# Altium schematic sheet VELOSTAT_SENSING.SchDoc
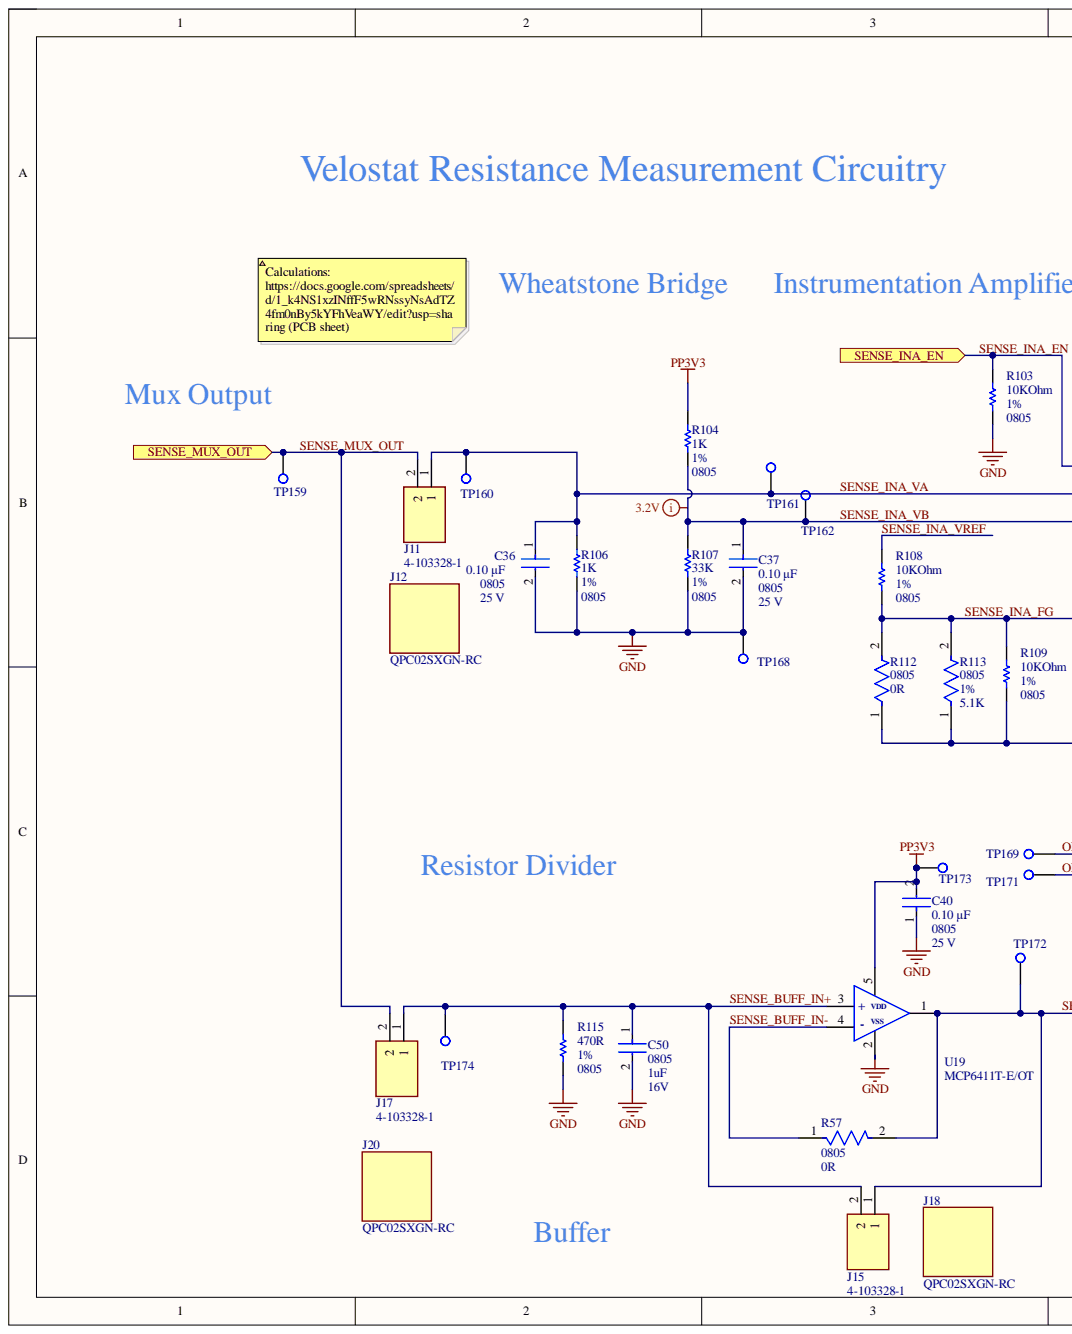
[diagram p0: VELOSTAT_SENSING.SchDoc, left]
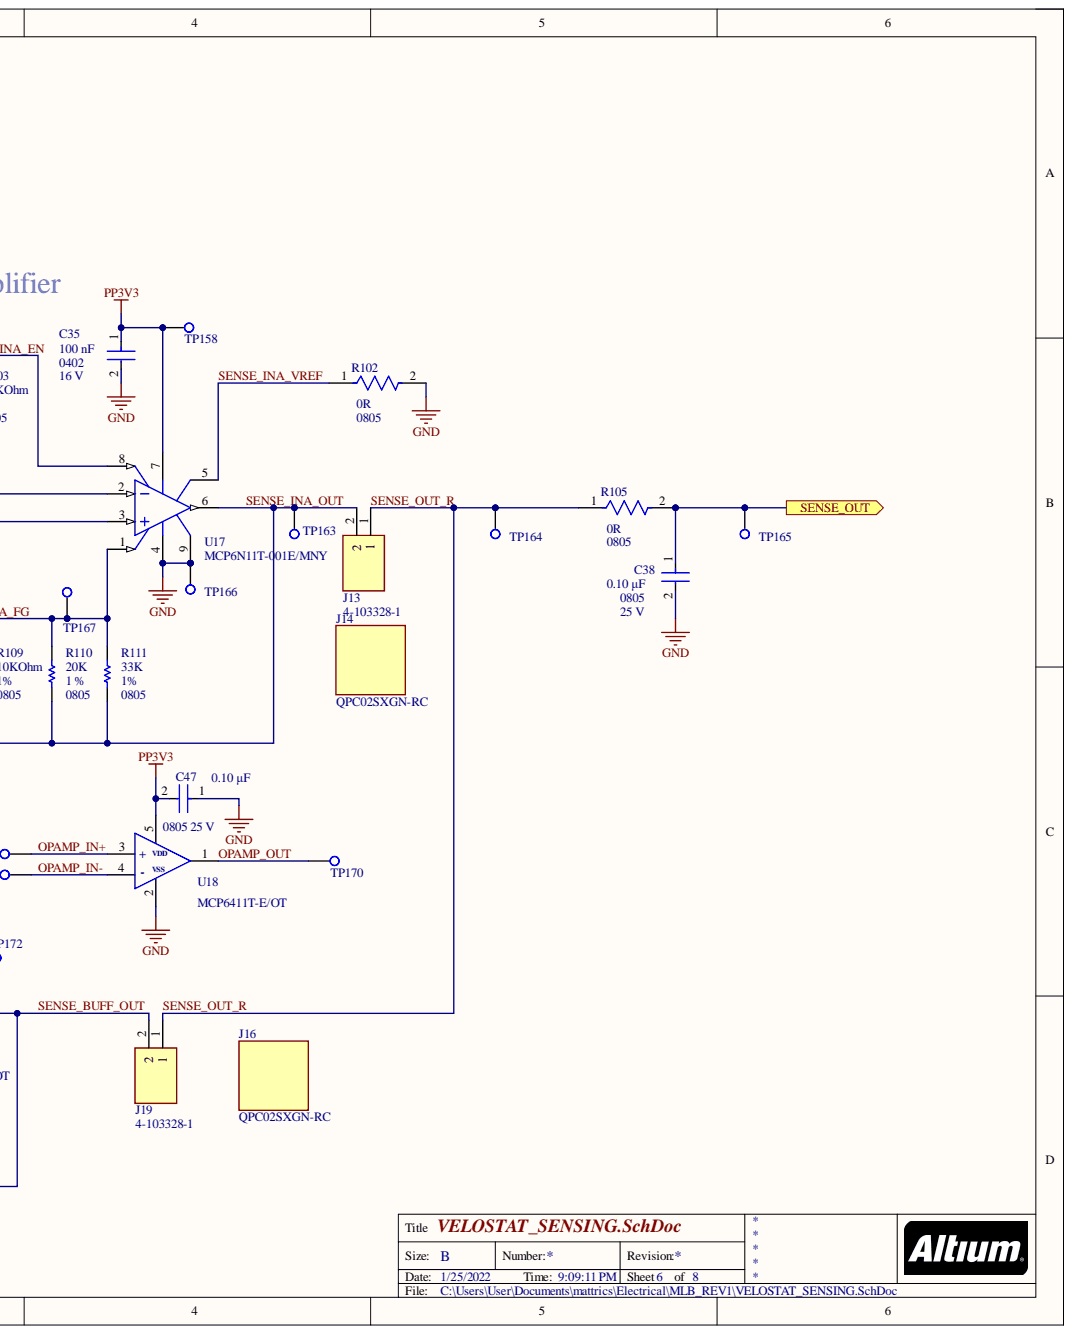
[diagram p1: VELOSTAT_SENSING.SchDoc, right]

## Parts
C35  value 100 nF  Capacitance: 100 nF  Package: 0402  [FP-CC0402-IPC_B]  (hidden comment: CC0402KRX7R7BB104)
C36  value 0.10 μF  Capacitance: 0.10 μF  Package: 0805  [FP-C0805C-DN-MFG]  (hidden comment: C0805C104M3RACTU)
C37  value 0.10 μF  Capacitance: 0.10 μF  Package: 0805  Voltage Rating: 25 V  [FP-C0805C-DN-MFG]  (hidden comment: C0805C104M3RACTU)
C38  value 0.10 μF  Package: 0805  Voltage Rating: 25 V  [FP-C0805C-DN-MFG]  (hidden comment: C0805C104M3RACTU)
C40  value 0.10 μF  Capacitance: 0.10 μF  Package: 0805  Voltage Rating: 25 V  [FP-C0805C-DN-MFG]  (hidden comment: C0805C104M3RACTU)
C47  value 0.10 μF  [FP-C0805C-DN-MFG]  (hidden comment: C0805C104M3RACTU)
C50  Capacitance: 1uF  Case Code (Imperial): 0805  Voltage: 16V  [FP-CL21-IPC_A]  (hidden comment: CL21B105KOFNNNE)
J11  4-103328-1  [TE_4-103328-1]
J12  QPC02SXGN-RC  [FP-QPC02SXGN-RC-MFG]
J13  4-103328-1  [TE_4-103328-1]
J14  QPC02SXGN-RC  [FP-QPC02SXGN-RC-MFG]
J15  4-103328-1  [TE_4-103328-1]
J16  QPC02SXGN-RC  [FP-QPC02SXGN-RC-MFG]
J17  4-103328-1  [TE_4-103328-1]
J18  QPC02SXGN-RC  [FP-QPC02SXGN-RC-MFG]
J19  4-103328-1  [TE_4-103328-1]
J20  QPC02SXGN-RC  [FP-QPC02SXGN-RC-MFG]
R57  Case Code (Imperial): 0805  Resistance: 0R  [FP-RMCF0805-MFG]  (hidden comment: RMCF0805ZT0R00)
R102  Case Code (Imperial): 0805  Resistance: 0R  [FP-RMCF0805-MFG]  (hidden comment: RMCF0805ZT0R00)
R103  value 10KOhm  PackageReference: 0805  Tolerance: 1%  [RESC2013X60X35NL10T20]  (hidden comment: RC0805FR-0710KL)
R104  Case Code (Imperial): 0805  Resistance: 1K  Tolerance: 1%  [RESC2013X60X35ML10T20]  (hidden comment: RC0805FR-071KL)
R105  Case Code (Imperial): 0805  Resistance: 0R  [FP-RMCF0805-MFG]  (hidden comment: RMCF0805ZT0R00)
R106  Case Code (Imperial): 0805  Resistance: 1K  Tolerance: 1%  [RESC2013X60X35ML10T20]  (hidden comment: RC0805FR-071KL)
R107  value 33K  Package: 0805  Tolerance: 1%  [RESC2013X70X40LL20T20]  (hidden comment: ERJ-6GEYJ333V)
R108  value 10KOhm  PackageReference: 0805  Tolerance: 1%  [RESC2013X60X35NL10T20]  (hidden comment: RC0805FR-0710KL)
R109  value 10KOhm  PackageReference: 0805  Tolerance: 1%  [RESC2013X60X35NL10T20]  (hidden comment: RC0805FR-0710KL)
R110  value 20K  Case-EIA: 0805  Tolerance: 1 %  [RESC0805(2012)_N]  (hidden comment: 20K 1% 0805(2012))
R111  value 33K  Package: 0805  Tolerance: 1%  [RESC2013X70X40LL20T20]  (hidden comment: ERJ-6GEYJ333V)
R112  Case Code (Imperial): 0805  Resistance: 0R  [FP-RMCF0805-MFG]  (hidden comment: RMCF0805ZT0R00)
R113  Case Code (Imperial): 0805  Resistance: 5.1K  Tolerance: 1%  [FP-CRCW0805-e3-MFG]  (hidden comment: CRCW08055K10FKEA)
R115  Package Reference: 0805  Resistance: 470R  Resistance Tolerance: 1%  [RESC2013X60X35LL10T20]  (hidden comment: RC0805FR-07470RL)
TP158  RCU-0C  [TP+195X110]  (value not drawn)
TP159  RCU-0C  [TP+195X110]  (value not drawn)
TP160  RCU-0C  [TP+195X110]  (value not drawn)
TP161  RCU-0C  [TP+195X110]  (value not drawn)
TP162  RCU-0C  [TP+195X110]  (value not drawn)
TP163  RCU-0C  [TP+195X110]  (value not drawn)
TP164  RCU-0C  [TP+195X110]  (value not drawn)
TP165  RCU-0C  [TP+195X110]  (value not drawn)
TP166  RCU-0C  [TP+195X110]  (value not drawn)
TP167  RCU-0C  [TP+195X110]  (value not drawn)
TP168  RCU-0C  [TP+195X110]  (value not drawn)
TP169  RCU-0C  [TP+195X110]  (value not drawn)
TP170  RCU-0C  [TP+195X110]  (value not drawn)
TP171  RCU-0C  [TP+195X110]  (value not drawn)
TP172  RCU-0C  [TP+195X110]  (value not drawn)
TP173  RCU-0C  [TP+195X110]  (value not drawn)
TP174  RCU-0C  [TP+195X110]  (value not drawn)
U17  MCP6N11T-001E/MNY  [TDFN-MN8]
U18  MCP6411T-E/OT  [SOT95P280X145-5N]
U19  MCP6411T-E/OT  [SOT95P280X145-5N]

## Ports
SENSE_INA_EN, SENSE_MUX_OUT, SENSE_OUT

## Nets
GND: C35.2 C36.2 C37.2 C38.2 C40.1 C47.1 C50.2 R102.2 R103.1 R106.1 R107.1 R115.1 TP166.1 TP168.1 U17.4 U17.9 U18.2 U19.2
PP3V3: C35.1 C40.2 C47.2 R104.2 TP158.1 TP173.1 U17.7 U18.5 U19.5
OPAMP_IN+: TP169.1 U18.3(+)
OPAMP_IN-: TP171.1 U18.4(-)
OPAMP_OUT: TP170.1 U18.1
SENSE_BUFF_IN+: C50.1 J15.2 J17.1 R115.2 TP174.1 U19.3(+)
SENSE_BUFF_IN-: R57.1 U19.4(-)
SENSE_BUFF_OUT: J15.1 J19.2 R57.2 TP172.1 U19.1
SENSE_INA_EN: R103.2 U17.8 ; port SENSE_INA_EN
SENSE_INA_FG: R108.1 R109.2 R110.2 R111.2 R112.2 R113.2 TP167.1 U17.1
SENSE_INA_OUT: J13.2 R109.1 R110.1 R111.1 R112.1 R113.1 TP163.1 U17.6
SENSE_INA_VA: C36.1 J11.1 R106.2 TP160.1 TP161.1 U17.2
SENSE_INA_VB: C37.1 R104.1 R107.2 TP162.1 U17.3
SENSE_INA_VREF: R102.1 R108.2 U17.5
SENSE_MUX_OUT: J11.2 J17.2 TP159.1 ; port SENSE_MUX_OUT
SENSE_OUT: C38.1 R105.2 TP165.1 ; port SENSE_OUT
SENSE_OUT_R: J13.1 J19.1 R105.1 TP164.1

## Library data of the parts
C35 = CMP-03422-000048-1: CAP CER 0.1UF 16V X7R 0402; Manufacturer: YAGEO; Automotive Grade: NA; Material: Ceramic; Temperature Coefficient: 30ppm/°C; Tolerance: 0.1; Automotive: No; Category: Cap; Component Kind: Standard; ComponentLink2Description: Datasheet; ComponentLink2URL: http://www.yageo.com/documents/recent/UPY-GP_NP0_16V-to-50V_16.pdf; Device Class L1: Passive Components; Device Class L2: Capacitors; Device Class L3: Ceramic Capacitors; Digikey Description: CAP CER 0.1UF 16V X7R 0402; Footprint Url: http://www.yageo.com/exep/pages/download/literatures/UPY-C_GEN_24.pdf; Height: 0.55mm; Ipc Land Pattern Name: CAPC10050X50; Lead Free: Yes; Library Name: MLBSchLib.SchLib; Manufacturer Part Number: CC0402KRX7R7BB104; Mouser Description: 0402 0.1 uF 16 V ±10 % Tolerance X7R SMT Multilayer Ceramic Capacitor; Octopart: https://octopart.com/cc0402krx7r7bb104-yageo-42244624; RoHS: TRUE; Supplier: Digi-Key; Supplier Part Number: 311-1338-1-ND; Temperature: -55°C to +125°C; Temperature Characteristic: X7R; Temperature Range High: +125°C; Temperature Range Low: -55°C; Voltage Rating: 16 V
C36-C38 C40 C47 = CMP-2007-00282-2: CAP CER 0.1UF 25V 20% X7R 0805; Manufacturer: KEMET; Material: Ceramic; Temperature Coefficient: 30ppm/°C; Tolerance: 0.2; Automotive: No; Category: Cap; Component Kind: Standard; ComponentLink2Description: Datasheet; ComponentLink2URL: https://content.kemet.com/datasheets/KEM_C1003_C0G_SMD.pdf; Device Class L1: Passive Components; Device Class L2: Capacitors; Device Class L3: Ceramic Capacitors; Digikey Description: CAP CER 0.1UF 25V 20% X7R 0805; Height: 0.88mm; Ipc Land Pattern Name: CAPC200125X78; Lead Free: Yes; Library Name: Altium Content Vault; Manufacturer Part Number: C0805C104M3RACTU; Mouser Description: Multilayer Ceramic Capacitors MLCC - SMD/SMT 25volts 0.1uF X7R 20%; Octopart: https://octopart.com/c0805c104m3ractu-kemet-9138612; RoHS: TRUE; Supplier: Digi-Key; Supplier Part Number: 399-C0805C104M3RAC7800CT-ND; Temperature: -55°C to +125°C; Temperature Characteristic: X7R; Temperature Range High: +125°C; Temperature Range Low: -55°C
  C36: Voltage Rating: 25 V
  C38: Capacitance: 0.10 μF
  C47: Capacitance: 0.10 μF; Package: 0805; Voltage Rating: 25 V
C50 = CMP-13271-003098-1: CL21 Series 0805 1uF 16V ±10% Tolerance X7R Multilayer Ceramic Chip Capacitor; Manufacturer: Samsung Electro-Mechanics; Case Code (Metric): 2012; Case/Package: 0805; Component Kind: Standard; Contact Plating: Tin; Depth: 1.25mm; Dielectric: X7R; Height: 1.25mm; Lead Free: Lead Free; Length: 2mm; Library Name: MLBSchLib.SchLib; Manufacturer Part Number: CL21B105KOFNNNE; Max Operating Temperature: 125°C; Min Operating Temperature: -55°C; Mount: Surface Mount; Package Quantity: 1; Packaging: Tape and Reel; Radiation Hardening: No; Supplier: Digi-Key; Supplier Part Number: 1276-1026-1-ND; Thickness: 0.053inch; Tolerance: 10%; Voltage Rating: 16V; Voltage Rating (DC): 16V; Width: 0.049inch
J11 J13 J15 J17 J19 = 4-103328-1: Connector Header Through Hole 2 position; Manufacturer: TE Connectivity AMP Connectors; MF: TE Connectivity; Availability: Unavailable; Component Kind: Standard; EU_RoHS_Compliance: Compliant; Library Name: MLBSchLib.SchLib; Manufacturer Part Number: 4-103328-1; MP: 4-103328-1; Package: None; Price: None; SnapEDA_Link: https://www.snapeda.com/parts/4-103328-1/TE%20Connectivity%20AMP%20Connectors/view-part/2384420/?ref=snap; Supplier: Digi-Key; Supplier Part Number: A121364-ND; TE_purchase_URL: https://www.te.com/usa-en/product-4-103328-1.html?te_bu=Cor&te_type=disp&te_campaign=seda_glo_cor-seda-global-disp-prtnr-fy19-seda-model-bom-cta_sma-317_1&elqCampaignId=32493
J12 J14 J16 J18 J20 = CMP-25666-000001-1: CONN JUMPER SHORTING .100" GOLD; Manufacturer: Sullins; Conn Orientation: Straight; Current Rating: 3A; Number Of Contacts: 2; Pitch: 2.54mm; Automotive: No; Category: Conn; Component Kind: Standard; ComponentLink2Description: Datasheet; ComponentLink2URL: https://s3.amazonaws.com/catalogspreads-pdf/PAGE128-129%20.100%20JUMPER.pdf; Contact Material: Gold; Device Class L1: Connectors; Device Class L2: unset; Device Class L3: unset; Digikey Description: CONN JUMPER SHORTING .100" GOLD; Footprint Url: https://drawings-pdf.s3.amazonaws.com/11510.pdf; Height: 6.17mm; Lead Free: Yes; Library Name: Altium Content Vault; Manufacturer Part Number: QPC02SXGN-RC; Octopart: https://octopart.com/qpc02sxgn-rc-sullins-19878795; Package: 0; RoHS: TRUE; Supplier: Digi-Key; Supplier Part Number: S9337-ND; Temperature: -40°C to +85°C; Temperature Range High: +85°C; Temperature Range Low: -40°C
R57 R102 R105 R112 = CMP-26527-000017-1: RES 0 OHM JUMPER 1/8W 0805; Manufacturer: Stackpole Electronics Inc; Max Power Dissipation: 125mW; Case Code (Metric): 2012; Case/Package: 0805; Component Kind: Standard; Composition: Thick Film; Contact Plating: Tin; Depth: 1.25mm; Features: Automotive AEC-Q200; Height: 0.026inch; Lead Free: Lead Free; Length: 2mm; Library Name: Altium Content Vault; Manufacturer Part Number: RMCF0805ZT0R00; Max Operating Temperature: 155°C; Military Standard: Not; Min Operating Temperature: -55°C; Mount: Surface Mount; Number of Terminations: 2; Packaging: Tape and Reel; Power Rating: 125mW; Radiation Hardening: No; Supplier: Digi-Key; Supplier Part Number: RMCF0805ZT0R00CT-ND; Width: 0.049inch
R103 R108 R109 = CMP-1659-00013-4: Chip Resistor, 10 KOhm, +/- 1%, 0.125 W, -55 to 155 degC, 0805 (2012 Metric), RoHS, Tape and Reel; Manufacturer: Yageo; Voltage Rating: 150 V; Component Kind: Standard; ComponentLink1Description: Manufacturer URL; ComponentLink1URL: http://www.yageo.com/; ComponentLink2Description: Datasheet; ComponentLink2URL: http://www.yageo.com/documents/recent/PYu-RC_Group_51_RoHS_L_04.pdf; DatasheetVersion: Rev. 4, 02/2016; Height: 0.5 mm; Length: 2 mm; Library Name: Altium Content Vault; Manufacturer Part Number: RC0805FR-0710KL; Max Operating Temperature: 155 degC; Min Operating Temperature: -55 degC; Mount: Surface Mount; Mounting Technology: Surface Mount; Package Quantity: 5000; PackageDescription: 2-Pin Surface Mounted Device, Body 2 x 1.25 mm; Packaging: Tape and Reel; Pins: 2; REACH SVHC: No SVHC; RoHSCompliant: true; Supplier: Digi-Key; Supplier Part Number: RC0805FR-0710KL
R104 R106 = CMP-2100-03671-1: Chip Resistor, 1 KOhm, +/- 1%, 125 mW, -55 to 155 degC, 0805 (2012 Metric), RoHS, Tape and Reel; Manufacturer: Yageo; Max Power Dissipation: 125mW; Temperature Coefficient: 100ppm/degreesC; Voltage Rating: 150V; Case Code (Metric): 2012; Case/Package: 0805; Component Kind: Standard; Composition: ThickFilm; Datasheet URL: http://www.yageo.com/NewPortal/yageodocoutput?fileName=/pdf/R-Chip/PYu-RC_Group_51_RoHS_L_5.pdf; Datasheet Version: Rev. 5, 10/2016; Depth: 1.25mm; Height: 0.024inch; Lead Free: LeadFree; Length: 0.079inch; Library Name: Altium Content Vault; Manufacturer Part Number: RC0805FR-071KL; Manufacturer URL: http://www.yageo.com/; Max Operating Temperature: 155degC; Military Standard: Not; Min Operating Temperature: -55degC; Number of Pins: 2; Number of Terminations: 2; Package Description: 2-Pin Surface Mounted Device, Body 2 x 1.25 mm; Package Quantity: 1; Package Reference: 0805; Packaging: TapeandReel; Power Rating: 125mW; REACH SVHC: Unknown; RoHSCompliant: true; Supplier: Digi-Key; Supplier Part Number: 311-1.00KCRCT-ND; Termination: SMD/SMT; Voltage Rating (DC): 150V; Width: 0.049inch
R107 R111 = CMP-2001-00401-1: Manufacturer: Panasonic; Component Kind: Standard; Library Name: Altium Content Vault; Manufacturer Part Number: ERJ-6GEYJ333V; Part Number: ERJ-6GEYJ333V; Supplier: Digi-Key; Supplier Part Number: P33KACT-ND
R110 = CMP-1013-00655-1: 20K 0.125W 1% 0805 (2012 Metric)  SMD; Manufacturer: YAGEO; Manufacturer Part Number: RC0805FR-0720KL; Case-Metric: 2012; Component Kind: Standard; Library Name: Altium Content Vault; Power: 0.125 W; Supplier: Digi-Key; Supplier Part Number: 311-20.0KCRCT-ND; Technology: SMT
R113 = CMP-2001-04024-2: RES Thick Film, 5.1kΩ, 1%, 0.125W, 100ppm/°C, 0805; Manufacturer: Vishay Dale; Manufacturer Part Number: CRCW08055K10FKEAC; Max Power Dissipation: 125mW; Voltage Rating: 150V; Case Code (Metric): 2012; Case/Package: 0805; Component Kind: Standard; Composition: Thick Film; Contact Plating: Tin; Depth: 1.25mm; Features: Automotive AEC-Q200; Height: 0.45mm; Lead Free: Lead Free; Length: 2mm; Library Name: Altium Content Vault; Max Operating Temperature: 155°C; Military Standard: Not; Min Operating Temperature: -55°C; Mount: Surface Mount; Number of Terminations: 2; Package Quantity: 10; Packaging: Tape and Reel; Power Rating: 125mW; Radiation Hardening: No; Supplier: Digi-Key; Supplier Part Number: 541-CRCW08055K10FKEACCT-ND; Temperature Coefficient: 100ppm/°C; Termination: SMD/SMT; Voltage Rating (DC): 150V; Weight: 0.000194oz; Width: 0.049inch
R115 = CMP-2000-06961-1: Chip Resistor, 470 Ohm, +/- 1%, 125 mW, -55 to 155 degC, 0805 (2012 Metric), RoHS, Tape and Reel; Manufacturer: Yageo; Power Rating: 250mW; Resistor Case Style: 0805[2012Metric]; Resistor Element Type: ThickFilm; SVHC: ToBeAdvised; Temperature Coefficient: ±100ppm/°C; Voltage Rating: 150V; Automotive Qualification Standard: -; Component Kind: Standard; Datasheet URL: http://www.yageo.com/documents/recent/PYu-RC_Group_51_RoHS_L_7.pdf; Datasheet Version: Rev. 7, 05/2017; Library Name: Altium Content Vault; Manufacturer Part Number: RC0805FR-07470RL; Manufacturer URL: http://www.yageo.com/; Mounting Technology: SurfaceMount; MSL: MSL1-Unlimited; Package Description: 2-Pin Surface Mounted Device, Body 2 x 1.25 mm; Packaging: Tape&Reel; Product Range: -; Supplier: Digi-Key; Supplier Part Number: 311-470CRCT-ND
TP158-TP174 = RCU-0C: Natural PC Test Point , Plating Surface Mount Mounting Type; Manufacturer: TE Connectivity AMP Connectors; Check_prices: https://www.snapeda.com/parts/RCU-0C/TE%20Connectivity/view-part/4791537/?ref=eda; Component Kind: Standard; Library Name: MLBSchLib.SchLib; Manufacturer Part Number: RCU-0C; Package: SON-6 TE Connectivity AMP Connectors; Purchase-URL: https://pricing.snapeda.com/search/part/RCU-0C/?ref=eda; SnapEDA_Link: https://www.snapeda.com/parts/RCU-0C/TE%20Connectivity/view-part/4791537/?ref=snap; Supplier: Digi-Key; Supplier Part Number: A106145CT-ND
U17 = CMP-0187-00751-2: None; Manufacturer: Microchip Technology; Bandwidth: 500kHz; Common Mode Rejection Ratio: 70dB; Input Bias Current: 10pA; Input Offset Voltage (Vos): 3mV; Max Supply Current: 800uA; Max Supply Voltage: 5.5V; Min Supply Voltage: 1.8V; Number of Amplifiers: 1; Number of Elements: 2; Power Supply Rejection Ratio (PSRR): 62dB; Slew Rate: 9V/µs; Case/Package: SOIC; Component Kind: Standard; Gain Bandwidth Product: 500kHz; Height: 0.75mm; Input Offset Voltage-Max: 3mV; Lead Free: Lead Free; Length: 3mm; Library Name: Altium Content Vault; Manufacturer Part Number: MCP6N11T-001E/MNY; Max Operating Temperature: 125°C; Min Operating Temperature: -40°C; Mount: Surface Mount; Nominal Supply Current: 800uA; Number of Channels: 1; Number of Circuits: 1; Number of Pins: 8; Package Quantity: 3300; Packaging: Tape and Reel; Radiation Hardening: No; REACH SVHC: No SVHC; RoHS Compliant: Yes; Simulation: MCP6N11_RevD; Supplier: Digi-Key; Supplier Part Number: MCP6N11T-001E/MNYCT-ND; Supply Current: 800uA; Unity Gain Bandwidth Product: 500kHz; Width: 2mm
U18 U19 = MCP6411T-E_OT: IC OPAMP GP 1 CIRCUIT SOT23-5; Manufacturer: Microchip; Arrow Part Number: MCP6411T-E/OT; Arrow Price/Stock: https://www.arrow.com/en/products/mcp6411t-eot/microchip-technology; Component Kind: Standard; Datasheet Link: http://ww1.microchip.com/downloads/en/DeviceDoc/20005791B.pdf; Height: 1.45mm; Library Name: MLBSchLib.SchLib; Manufacturer Part Number: MCP6411T-E/OT; Mouser Part Number: 579-MCP6411T-E/OT; Mouser Price/Stock: https://www.mouser.com/Search/Refine.aspx?Keyword=579-MCP6411T-E%2FOT; Supplier: Digi-Key; Supplier Part Number: MCP6411T-E/OTCT-ND
